# Altium schematic sheet Serial_Interface.SchDoc(Serial_Interface)
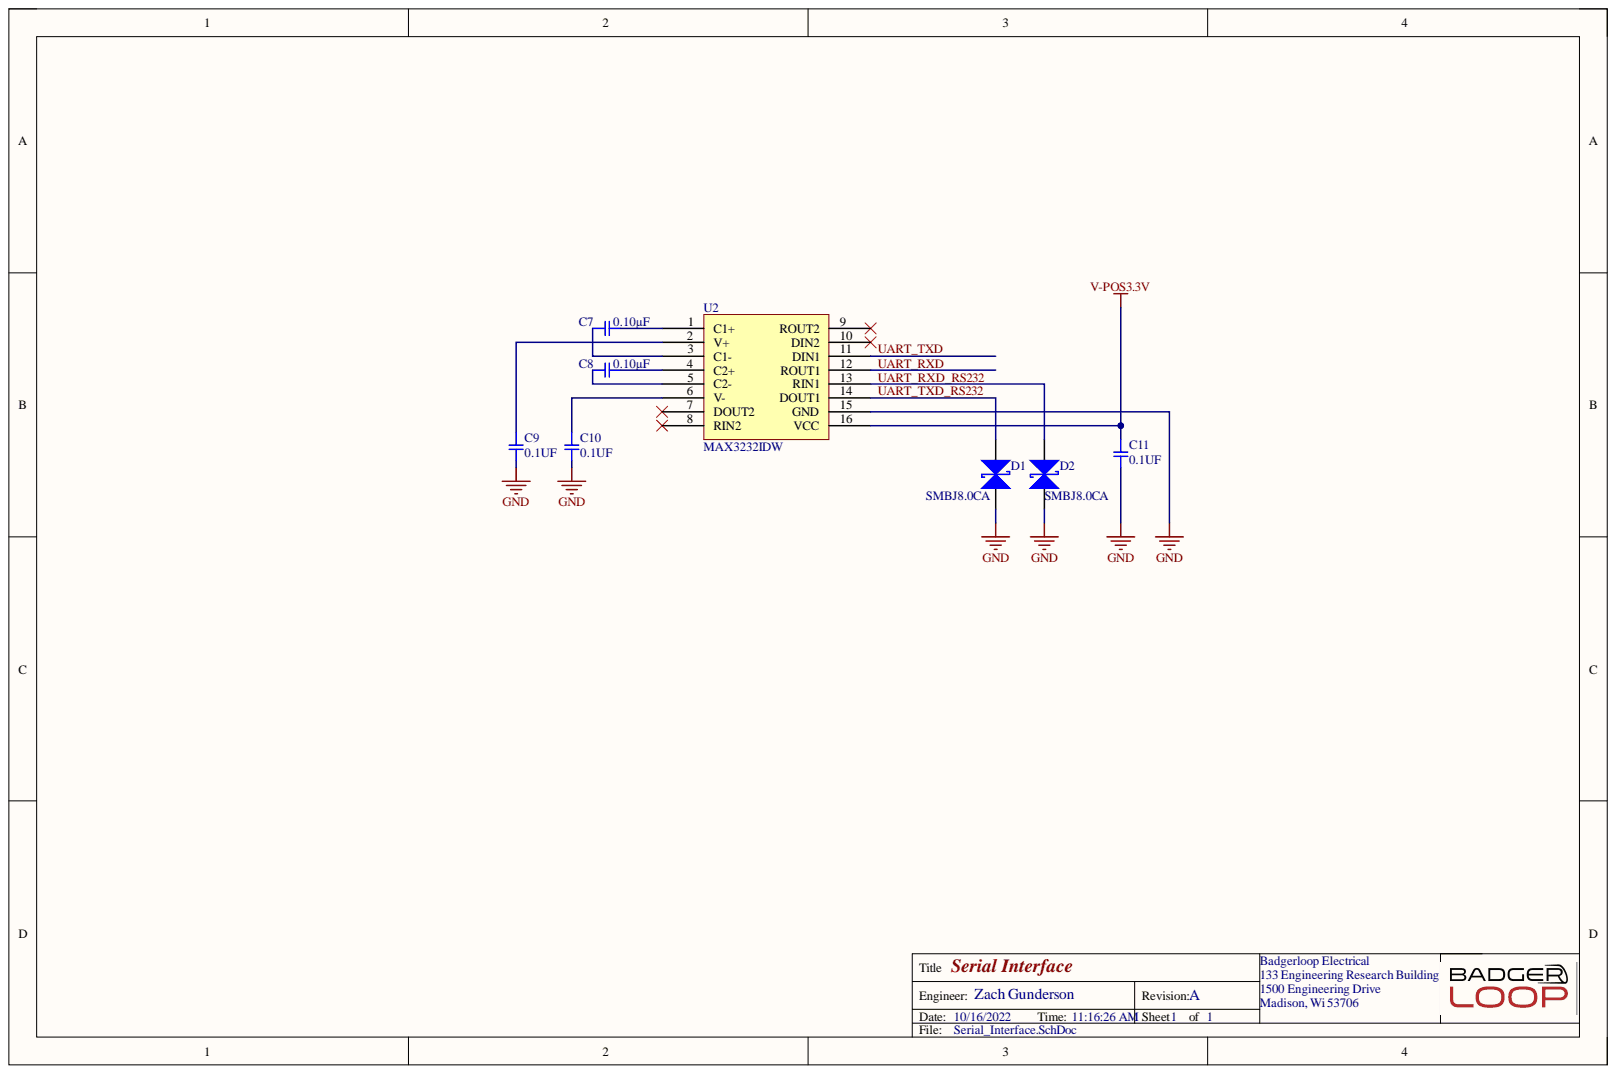
[diagram p0: Serial_Interface.SchDoc]

## Parts
C7  0.10µF  [SMD-0805C]
C8  0.10µF  [SMD-0805C]
C9  0.1UF  [SMD-0805C]
C10  0.1UF  [SMD-0805C]
C11  0.1UF  [SMD-0805C]
D1  SMBJ8.0CA  [DIOM4436X26N]
D2  SMBJ8.0CA  [DIOM4436X26N]
U2  MAX3232IDW  [SOP127P1060X175-16N]

## Nets
GND: C9.2 C10.2 C11.2 D1.1 D2.1 U2.15(GND)
V-POS3.3V: C11.1 U2.16(VCC)
UART_RXD: U2.12(ROUT1)
UART_RXD_RS232: D2.2 U2.13(RIN1)
UART_TXD: U2.11(DIN1)
UART_TXD_RS232: D1.2 U2.14(DOUT1)
NetC7_1: C7.1 U2.3(C1-)
NetC7_2: C7.2 U2.1(C1+)
NetC8_1: C8.1 U2.5(C2-)
NetC8_2: C8.2 U2.4(C2+)
NetC9_1: C9.1 U2.2(V+)
NetC10_1: C10.1 U2.6(V-)

Not connected: U2 7(DOUT2) 8(RIN2) 9(ROUT2) 10(DIN2)

## Library data of the parts
C7 C8 = GRM219R71C104JA01D: CAP CER 0.1UF 16V X7R 0805; Manufacturer: Murata Electronics North America; @ qty: 0; Minimum Quantity: 1; Tolerance: ±5%; Unit Price (USD): 0.12; Applications: General Purpose; Component Kind: Standard; Datasheets: -; Digi-Key Part Number: 490-12557-1-ND; Factory Stock: 0; Features: -; Height - Seated (Max): -; Image: //media.digikey.com/Renders/Murata%20Renders/0805%20(2012%20Metric)%20.85mm.jpg; Lead Spacing: -; Lead Style: -; Library Name: capacitors.SchLib; Mounting Type: Surface Mount, MLCC; Operating Temperature: -55°C ~ 125°C; Package / Case: 0805 (2012 Metric); Packaging: Cut Tape (CT); Part Number: GRM219R71C104JA01D; Part Status: Active; Quantity Available: 6635; Ratings: -; Series: GRM; Size / Dimension: 0.079" L x 0.049" W (2.00mm x 1.25mm); Temperature Coefficient: X7R; Thickness (Max): 0.037" (0.95mm); Value: 0.10µF; Voltage: 16V
C9-C11 = VJ0805Y104KXXCW1BC: CAP CER 0.1uF 25V X7R 0805; Manufacturer: Vishay; Manufacturer Series: VJ; Capacitance: 100nF; Capacitor Type: Ceramic; Multilayer: Yes; Negative Tolerance: 10%; Category: Ceramic Capacitors; Component Kind: Standard; ComponentLink1Description: https://datasheet.ciiva.com:443/pdfs/VipMasterIC/IC/BEYS/BEYSS01735/BEYSS01735-1.pdf?src-supplier=IHS+Markit; ComponentLink1URL: https://datasheet.ciiva.com:443/pdfs/VipMasterIC/IC/BEYS/BEYSS01735/BEYSS01735-1.pdf?src-supplier=IHS+Markit; ComponentLink2Description: https://datasheet.ciiva.com/176/vjw1bcbascomseries-176875.pdf?src-supplier=Digi-Key; ComponentLink2URL: https://datasheet.ciiva.com/176/vjw1bcbascomseries-176875.pdf?src-supplier=Digi-Key; ComponentLink3Description: https://datasheet.ciiva.com/11491/vjw1bcbascomseries-11491758.pdf?src-supplier=Avnet; ComponentLink3URL: https://datasheet.ciiva.com/11491/vjw1bcbascomseries-11491758.pdf?src-supplier=Avnet; Dielectric Material: Ceramic; JESD-609 Code: e3; Library Name: capacitors.SchLib; Manufacturer Part Number: VJ0805Y104KXXCW1BC; Max Operating Temperature: 125°C; Min Operating Temperature: -55°C; Mount: Surface Mount; Number of Pins: 2; Package Shape: Rectangular; Packaging: Tape and Reel; Positive Tolerance: 10%; Pricing 1: 1=0.25000, 10=0.17100, 50=0.11380, 100=0.09680, 500=0.06832, 1000=0.05978 (USD); Rated DC Voltage (URdc): 25V; REACH SVHC: Yes; RoHS: Yes; RoHS Compliant: Yes; Size Code: 0805; Stock 1: 38794; Supplier 1: Digi-Key; Supplier Part Number 1: 720-1537-1-ND; Temperature Characteristics Code: X7R; Terminal Finish: Tin; Termination: Wraparound
D1 D2 = SMBJ8.0CA: TVS DIODE 8V 13.6V DO214AA; Manufacturer: Littelfuse; Breakdown Voltage: 8.89V; Direction: Bidirectional; Leakage Current: 100uA; Max Breakdown Voltage: 9.83V; Max Power Dissipation: 600W; Max Surge Current: 44.2A; Number of Bidirectional Channels: 1; Power Line Protection: No; Test Current: 1mA; Case/Package: SMB; Clamping Voltage: 13.6V; Component Kind: Standard; ComponentLink1Description: http://www.littelfuse.com/~/media/electronics/datasheets/tvs_diodes/littelfuse_tvs_diode_smbj_datasheet.pdf.pdf?src-supplier=Digi-Key; ComponentLink1URL: http://www.littelfuse.com/~/media/electronics/datasheets/tvs_diodes/littelfuse_tvs_diode_smbj_datasheet.pdf.pdf?src-supplier=Digi-Key; ComponentLink2Description: http://www.littelfuse.com/data/en/Data_Sheets/Littelfuse_TVS-Diode_SMBJ.pdf?src-supplier=Element14; ComponentLink2URL: http://www.littelfuse.com/data/en/Data_Sheets/Littelfuse_TVS-Diode_SMBJ.pdf?src-supplier=Element14; Composition: Zener; Height: 2.44mm; Lead Free: Lead Free; Length: 5.59mm; Library Name: misc.SchLib; Max Operating Temperature: 150°C; Min Breakdown Voltage: 8.89V; Min Operating Temperature: -65°C; Mount: Surface Mount; Number of Channels: 1; Number of Elements: 1; Number of Pins: 2; Operating Supply Voltage: 8V; Package Quantity: 3000; Packaging: Tape and Reel; Peak Pulse Current: 44.2A; Peak Pulse Power: 600W; Polarity: Bidirectional; Power Dissipation: 600W; Pricing 1: 1=0.39, 10=0.313, 25=0.2864, 100=0.1798, 250=0.17784, 500=0.1665, 1000=0.11322 (USD); REACH SVHC: No SVHC; Reverse Breakdown Voltage: 8.89V; Reverse Standoff Voltage: 8V; Stock 1: 700; Supplier 1: Digi-Key; Supplier Part Number 1: SMBJ8.0CALFCT-ND; Termination: SMD/SMT; Voltage Rating (DC): 8V; Width: 3.94mm
U2 = MAX3232IDW: IC DRVR/RCVR MULTCH RS232 16SOIC; Manufacturer: Texas Instruments; Data Rate: 250Kbps; Logic Function: Transceiver,Receiver; Max Supply Voltage: 5.5V; Min Supply Voltage: 3V; Nominal Supply Current: 1mA; Number of Drivers: 2; Number of Transceivers: 1; Operating Supply Voltage: 5V; Output Current: 60mA; Output Voltage: 5.4V; Simplex/Duplex: Full Duplex; Case/Package: SOIC; Component Kind: Standard; ComponentLink1Description: http://www.farnell.com/datasheets/1823586.pdf?src-supplier=Element14; ComponentLink1URL: http://www.farnell.com/datasheets/1823586.pdf?src-supplier=Element14; ComponentLink2Description: http://www.ti.com/lit/ds/symlink/max3232.pdf?src-supplier=Digi-Key; ComponentLink2URL: http://www.ti.com/lit/ds/symlink/max3232.pdf?src-supplier=Digi-Key; ComponentLink3Description: http://focus.ti.com/lit/ds/symlink/max3232.pdf?src-supplier=Avnet+Europe; ComponentLink3URL: http://focus.ti.com/lit/ds/symlink/max3232.pdf?src-supplier=Avnet+Europe; ComponentLink4Description: http://www.produktinfo.conrad.com/datenblaetter/1100000-1199999/001187156-da-01-en-IC_DRVR_RCVR_MULT_MAX3232IDW_SOIC_16_TID.pdf?src-supplier=Conrad; ComponentLink4URL: http://www.produktinfo.conrad.com/datenblaetter/1100000-1199999/001187156-da-01-en-IC_DRVR_RCVR_MULT_MAX3232IDW_SOIC_16_TID.pdf?src-supplier=Conrad; Height: 2.35mm; Lead Free: Lead Free; Length: 10.28mm; Library Name: ic.SchLib; Max Operating Temperature: 85°C; Min Operating Temperature: -40°C; Mount: Surface Mount; Number of Pins: 16; Number of Receivers: 2; Number of Transmitters: 2; Package Quantity: 40; Packaging: Rail/Tube; Pricing 1: 1=2.15, 10=1.927, 25=1.818, 100=1.4543, 250=1.27252, 500=1.23618, 1000=0.98167, 2500=0.9604 (USD); Radiation Hardening: No; REACH SVHC: No SVHC; RoHS Compliant: Yes; Stock 1: 419; Supplier 1: Digi-Key; Supplier Part Number 1: 296-26159-5-ND; Weight: 0.014829oz; Width: 7.52mm
